# Altium schematic sheet Atmega328p.SchDoc(Atmega328p)
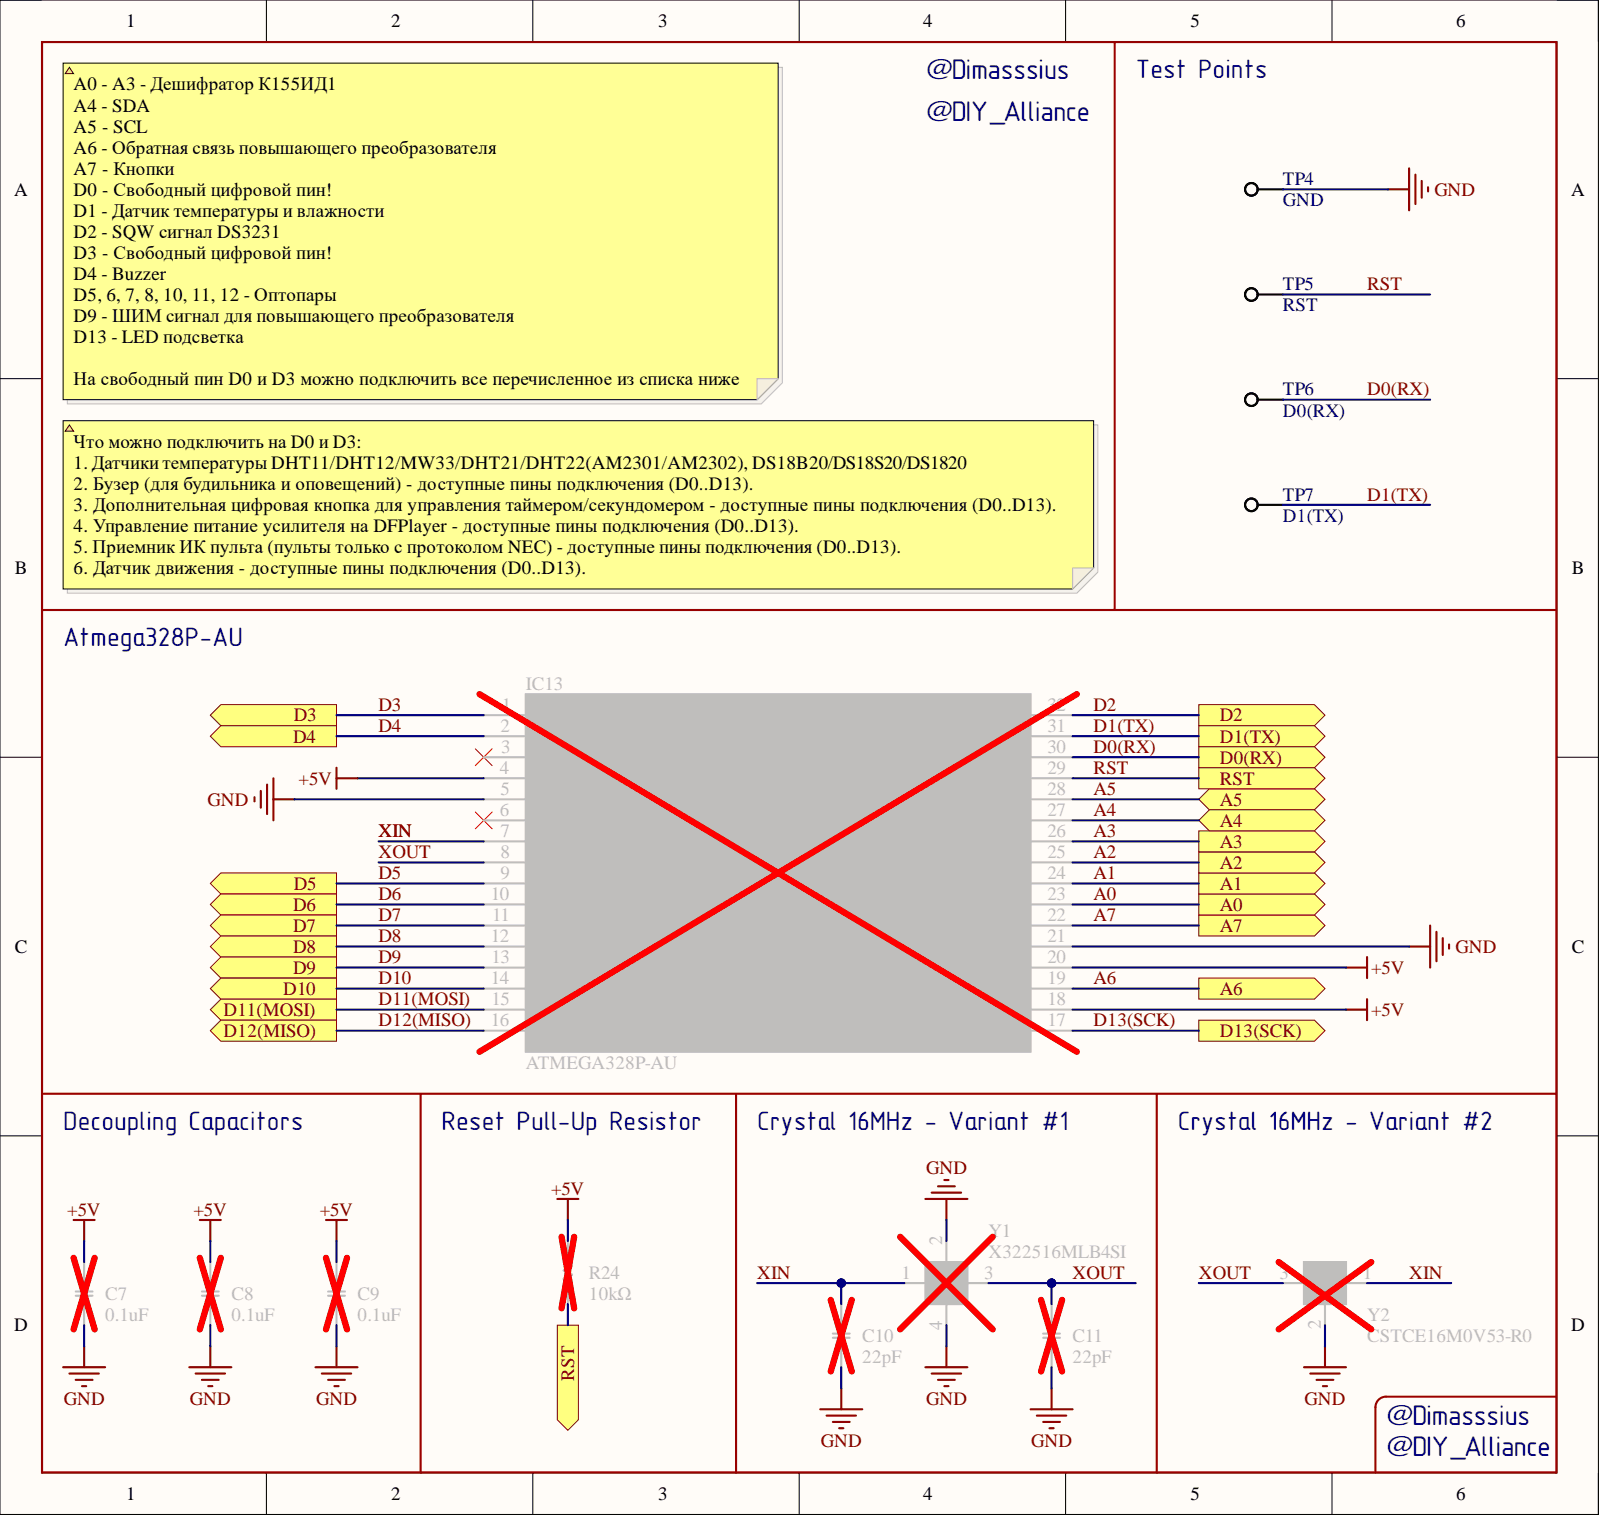
[diagram p0: Atmega328p.SchDoc]

## Parts
C7  0.1uF  [CAP_SMD_MLCC_C0603_(M1608)_C0402A_(M1005A)]
C8  0.1uF  [CAP_SMD_MLCC_C0603_(M1608)_C0402A_(M1005A)]
C9  0.1uF  [CAP_SMD_MLCC_C0603_(M1608)_C0402A_(M1005A)]
C10  22pF  [CAP_SMD_MLCC_C0603_(M1608)_C0402A_(M1005A)]
C11  22pF  [CAP_SMD_MLCC_C0603_(M1608)_C0402A_(M1005A)]
IC13  ATMEGA328P-AU  [QFP80P900X900X120-32N WITH SPI INTERFACE 2.54]
R24  10kΩ  [RES_SMD_R0603_(M1608)_R0402A_(M1005A)]
TP4  GND  [Test Point]  (no BOM)
TP5  RST  [Test Point]  (no BOM)
TP6  D0(RX)  [Test Point]  (no BOM)
TP7  D1(TX)  [Test Point]  (no BOM)
Y1  X322516MLB4SI  [XTL_4_3225]
Y2  CSTCE16M0V53-R0  [XTL_3_3213]

## Ports
A0, A1, A2, A3, A4, A5, A6, A7, D0(RX), D1(TX), D2, D3, D4, D5, D6, D7, D8, D9, D10, D11(MOSI), D12(MISO), D13(SCK), RST

## Nets
+5V: C7.2 C8.1 C9.1 IC13.4(VCC_1) IC13.18(AVCC) IC13.20(AREF) R24.2
GND: C7.1 C8.2 C9.2 C10.2 C11.1 IC13.5(GND_2) IC13.21(GND_3) TP4.1 Y1.2 Y1.4 Y2.2
A0: IC13.23(PC0) ; port A0
A1: IC13.24(PC1) ; port A1
A2: IC13.25(PC2) ; port A2
A3: IC13.26(PC3) ; port A3
A4: IC13.27(PC4/SDA) ; port A4
A5: IC13.28(PC5/SCL) ; port A5
A6: IC13.19(ADC6) ; port A6
A7: IC13.22(ADC7) ; port A7
D0(RX): IC13.30(PD0/RXD) TP6.1 ; port D0(RX)
D1(TX): IC13.31(PD1/TXD) TP7.1 ; port D1(TX)
D2: IC13.32(PD2) ; port D2
D3: IC13.1(PD3) ; port D3
D4: IC13.2(PD4) ; port D4
D5: IC13.9(PD5) ; port D5
D6: IC13.10(PD6) ; port D6
D7: IC13.11(PD7) ; port D7
D8: IC13.12(PB0) ; port D8
D9: IC13.13(PB1) ; port D9
D10: IC13.14(PB2) ; port D10
D11(MOSI): IC13.15(PB3/MOSI) ; port D11(MOSI)
D12(MISO): IC13.16(PB4/MISO) ; port D12(MISO)
D13(SCK): IC13.17(PB5/SCK) ; port D13(SCK)
RST: IC13.29(RESET) R24.1 TP5.1 ; port RST
XIN: C10.1 IC13.7(XTAL1) Y1.1 Y2.1
XOUT: C11.2 IC13.8(XTAL2) Y1.3 Y2.3

Not connected: IC13 3(GND_1) 6(VCC_2)

## Library data of the parts
C7-C9 = CAP_SMD_MLCC_0.1UF_6.3V: Capacitor, Ceramic (MLCC), SMD, 100nF, 6.3V, ±10%, X7R, Multilayer Ceramic Capacitors MLCC - SMD/SMT, ROHS; Manufacturer: Murata Electronics North America; Part Number: LLM315R71C104MA11L; @ qty: 0; Minimum Quantity: 1; Tolerance: ±20%; Unit Price (USD): 0.9; Voltage: 16V; Applications: Bypass, Decoupling; Component Kind: Standard; Datasheets: http://www.murata.com/~/media/webrenewal/support/library/catalog/products/capacitor/mlcc/c02e.pdf; Digi-Key Part Number: 490-4495-1-ND; Factory Stock: 0; Features: Low ESL (Multi-Terminal); Height - Seated (Max): -; Image: //media.digikey.com/Renders/Murata%20Renders/1206(LLM315).jpg; Lead Spacing: -; Lead Style: -; Library Name: Capacitors.SchLib; Mounting Type: Surface Mount, MLCC; Operating Temperature: -55°C ~ 125°C; Package / Case: -; Packaging: Cut Tape (CT); Part Status: Active; Quantity Available: 2915; Ratings: -; Series: LLM; Size / Dimension: -; Temperature Coefficient: X7R; Thickness (Max): -; Value: 0.1uF
C10 C11 = CAP_SMD_MLCC_22PF_50V: Capacitor, Ceramic (MLCC), SMD, 22pF, 50V, ±5%, C0G, Multilayer Ceramic Capacitors MLCC - SMD/SMT, ROHS; Manufacturer: Murata Electronics North America; Part Number: GRM319R71C224KA01D; @ qty: 0; Minimum Quantity: 1; Temperature Coefficient: X7R; Tolerance: ±10%; Unit Price (USD): 0.26; Voltage: 16V; Applications: General Purpose; Component Kind: Standard; Datasheets: http://www.murata.com/~/media/webrenewal/support/library/catalog/products/capacitor/mlcc/c02e.pdf; Digi-Key Part Number: 490-11608-1-ND; Factory Stock: 0; Features: -; Height - Seated (Max): -; Image: //media.digikey.com/Renders/Murata%20Renders/GR331BD72E473KW01L.jpg; Lead Spacing: -; Lead Style: -; Library Name: Capacitors.SchLib; Mounting Type: Surface Mount, MLCC; Operating Temperature: -55°C ~ 125°C; Package / Case: -; Packaging: Cut Tape (CT); Part Status: Active; Quantity Available: 10161; Ratings: -; Series: GRM; Size / Dimension: -; Thickness (Max): -; Value: 22pF
IC13 = ATMEGA328P-AU: Microcontroller, TQFP-32(7x7), AVR, 20MHz, Microcontrollers (MCU/MPU/SOC), ROHS; Component Kind: Standard; Datasheet Link: https://componentsearchengine.com/Datasheets/2/ATMEGA328P-AU.pdf; Height: 1.2mm; Library Name: SamacSys.SchLib; Value: ATMEGA328P-AU
R24 = RES_SMD_10KΩ: Resistor, Thick Film Resistor, SMD, 10kΩ, ±5%, Chip Resistor - Surface Mount, ROHS; Component Kind: Standard; Library Name: Resistors.SchLib; Value: 10kΩ
TP4-TP7 = Test Point: Description: Test Point; Library Name: SamacSys.SchLib
  TP4: Value: GND
  TP5: Value: RST
  TP6: Value: D0(RX)
  TP7: Value: D1(TX)
Y1 = X322516MLB4SI: Crystal Oscillator, SMD, 3225(3.2x2.5), 9pF, Crystals ROHS; Component Kind: Standard; Datasheet Link: https://datasheet.datasheetarchive.com/originals/distributors/Datasheets_SAMA/e448b11b6d77781431a8823f463adabd.pdf; Height: 0.9mm; Library Name: SamacSys.SchLib; Value: X322516MLB4SI
Y2 = CSTCE16M0V53-R0: Ceramic Resonators, SMD, SMD3213-3P, 3.2x1.3mm,16MHz, 15pF, 40Ω, Ceramic Resonators, ROHS; Component Kind: Standard; Datasheet Link: https://www.murata.com/en-sg/products/productdetail?partno=CSTCE16M0V53-R0; Height: 1mm; Library Name: SamacSys.SchLib; Value: CSTCE16M0V53-R0
